# Altium schematic sheet [12]-CAMERA_POWER.SchDoc([12]-CAMERA_POWER)
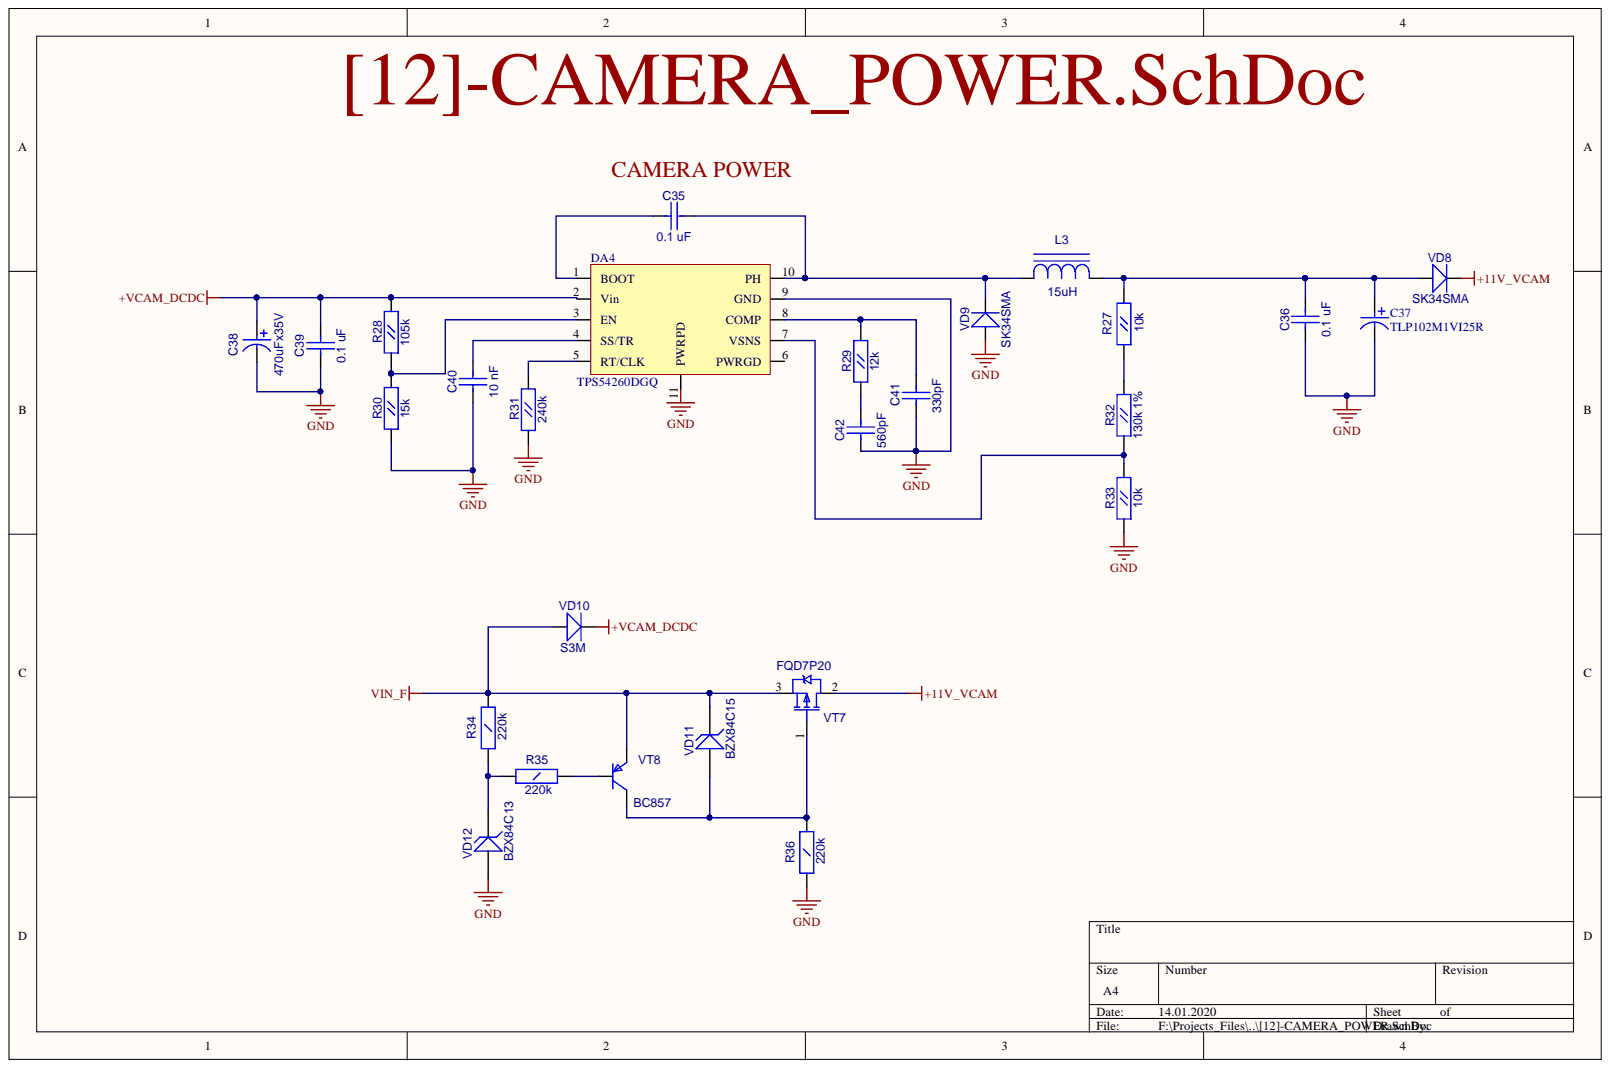
[diagram p0: [12]-CAMERA_POWER.SchDoc]

## Parts
C35  0.1 uF  [CC0603]
C36  0.1 uF  [CC0603]
C37  TLP102M1VI25R  [CAP_13x26]
C38  470uFx35V  [CC16X10_CAP0.5(1)(Primary)]
C39  0.1 uF  [CC0603]
C40  10 nF  [CC0603]
C41  330pF  [CC0603]
C42  560pF  [CC0603]
DA4  TPS54260DGQ  [S-PDSO-G10]
L3  15uH  [SDR0805]
R27  10k  [RC0603]
R28  105k  [RC0603]
R29  12k  [RC0603]
R30  15k  [RC0603]
R31  240k  [RC0603]
R32  130k 1%  [RC0603]
R33  10k  [RC0603]
R34  220k  [RC1206]
R35  220k  [RC1206]
R36  220k  [RC1206]
VD8  SK34SMA  [SMA]
VD9  SK34SMA  [SMA]
VD10  S3M  [SMC]
VD11  BZX84C15  [SOT-23(123)]
VD12  BZX84C13  [SOT-23(123)]
VT7  FQD7P20  [D-PAK(TO-252AA)]
VT8  BC857  [SOT-23(123)]

## Nets
+11V_VCAM: VD8.2 VT7.2
+VCAM_DCDC: C38.2 C39.1 DA4.2(Vin) R28.2 VD10.2
GND: C36.2 C37.1 C38.1 C39.2 C40.2 C41.2 C42.2 DA4.9(GND) DA4.11(PWRPD) R30.1 R31.1 R33.1 R36.1 VD9.1 VD12.1
VIN_F: R34.2 VD10.1 VD11.3 VT7.3 VT8.2
NetC35_1: C35.1 DA4.10(PH) L3.1 VD9.2
NetC35_2: C35.2 DA4.1(BOOT)
NetC36_1: C36.1 C37.2 L3.2 R27.2 VD8.1
NetC40_1: C40.1 DA4.4(SS/TR)
NetC41_1: C41.1 DA4.8(COMP) R29.2
NetC42_1: C42.1 R29.1
NetDA4_3: DA4.3(EN) R28.1 R30.2
NetDA4_5: DA4.5(RT/CLK) R31.2
NetDA4_7: DA4.7(VSNS) R32.1 R33.2
NetR27_1: R27.1 R32.2
NetR34_1: R34.1 R35.1 VD12.3
NetR35_2: R35.2 VT8.1
NetR36_2: R36.2 VD11.1 VT7.1 VT8.3

Not connected: DA4 6(PWRGD)

## Library data of the parts
C35 C36 C39-C42 = CC0603: Description: CC0603; Component Kind: Standard; Library Name: gprs_modem_2.SchLib
  C35 C36 C39: Value: 0.1 uF
  C40: Value: 10 nF
  C41: Value: 330pF
  C42: Value: 560pF
C37 = SUPERCAP_10F: Value: TLP102M1VI25R; Component Kind: Standard; Library Name: fizik_lib (1).SchLib
C38 = CAP0.5(1): Value: 470uFx35V; Description: CAP0.5(1); Component Kind: Standard; Library Name: gprs_modem_2.SchLib
L3 = SDR0805: Value: 15uH; Description: SDR0805; Component Kind: Standard; Library Name: gprs_modem_2.SchLib
R27-R33 = RC0603: Description: RC0603; Component Kind: Standard
  R27 R33: Value: 10k
  R28: Value: 105k
  R29: Value: 12k
  R30: Value: 15k
  R31: Value: 240k
  R32: Value: 130k 1%
  R27: Library Name: fizik_lib.SchLib
  R28-R33: Library Name: gprs_modem_2.SchLib
R34-R36 = RC1206: Value: 220k; Description: RC1206; Component Kind: Standard; Library Name: fizik_lib.SchLib
VD8 VD9 = SK34SMA: Schottky Rectifier; Value: {Value}; Component Kind: Standard; Library Name: gprs_modem.SchLib
VD10 = S3A: Schottky Rectifier; Value: S3M; Component Kind: Standard; Library Name: fizik_lib.SchLib
VD11 VD12 = BZX84: Zener Voltage Regulator Diode; Component Kind: Standard; Library Name: fizik_lib.SchLib
  VD11: Value: BZX84C15
  VD12: Value: BZX84C13
VT7 = IRFR9220: HEXFET Power MOSFET; OriginalName: IRLML5103; ComponentField7: Published 8-Jun-2000; Value: FQD7P20; Component Kind: Standard; Library Name: gprs_modem_2.SchLib
VT8 = BC857B: PNP 100ma transistor; OriginalName: BC846BLT1; ComponentField7: Published 29-Sep-2000; Value: BC857; Simulation: BC846BLT1; Component Kind: Standard; Library Name: gprs_modem_2.SchLib
DA4 = TPS54260DGQ: Component Kind: Standard; Library Name: gprs_modem_2.SchLib
